# Altium schematic sheet pca20054_sheet5_connectors.SchDoc
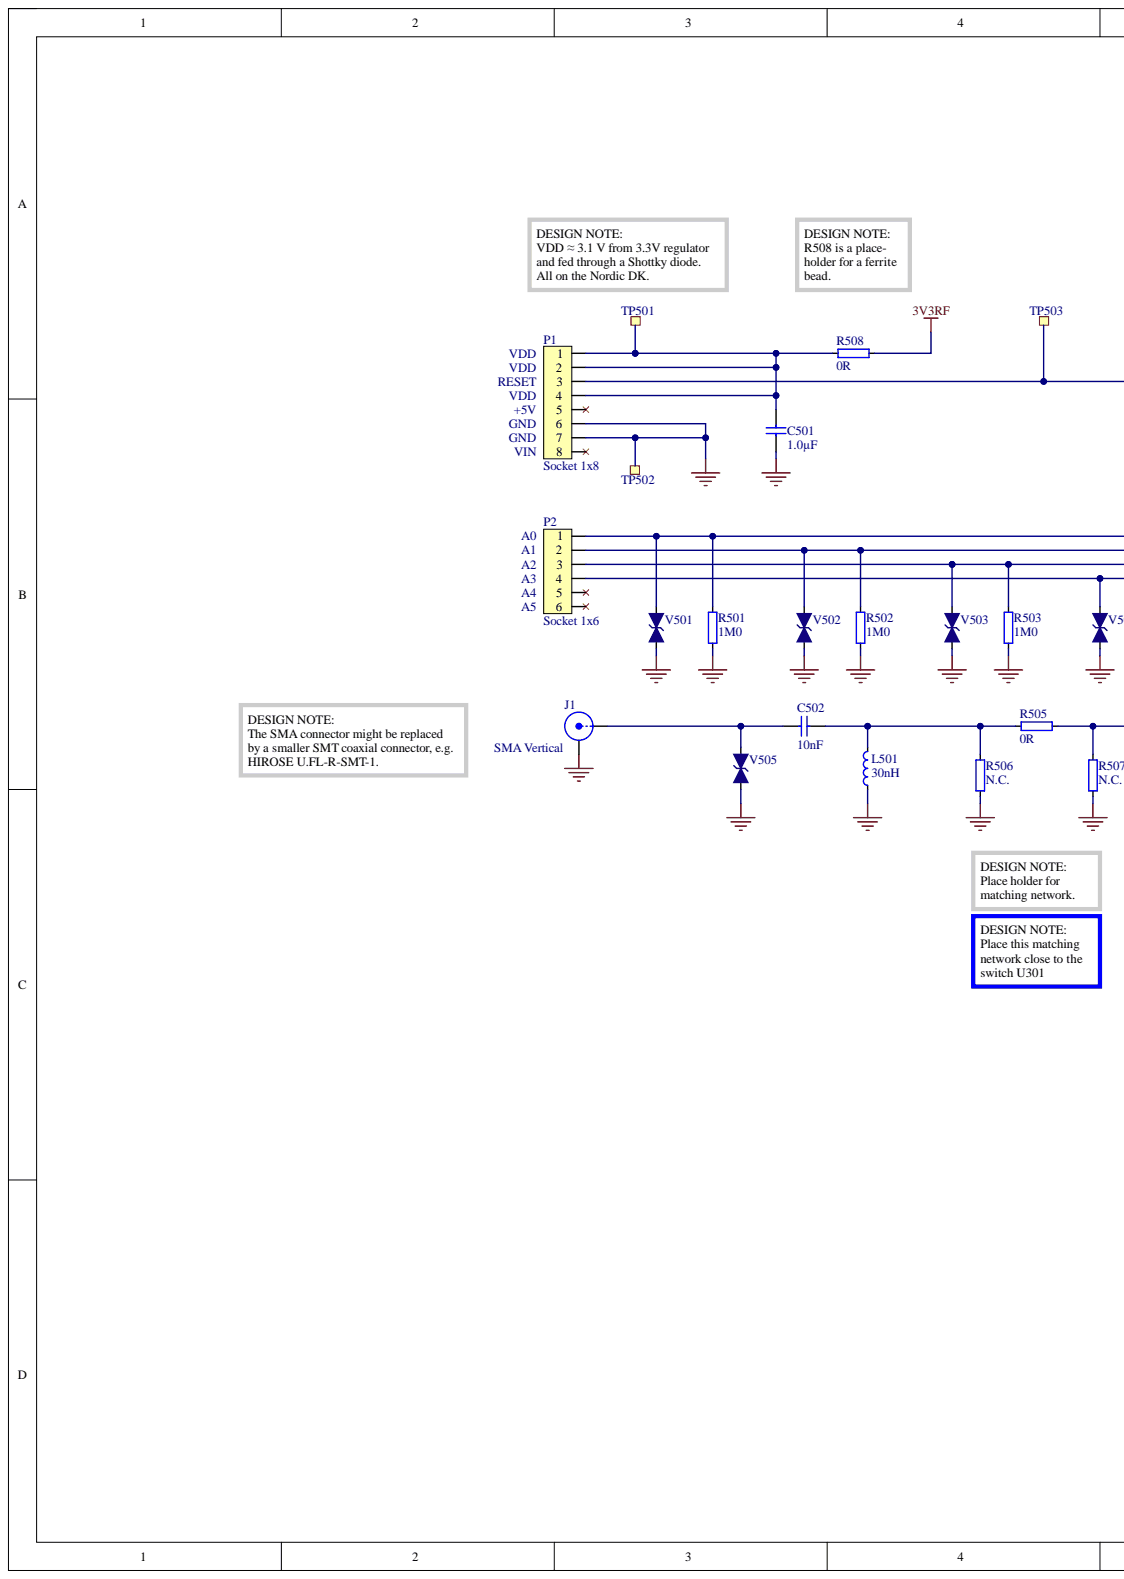
[diagram p0: pca20054_sheet5_connectors.SchDoc, left]
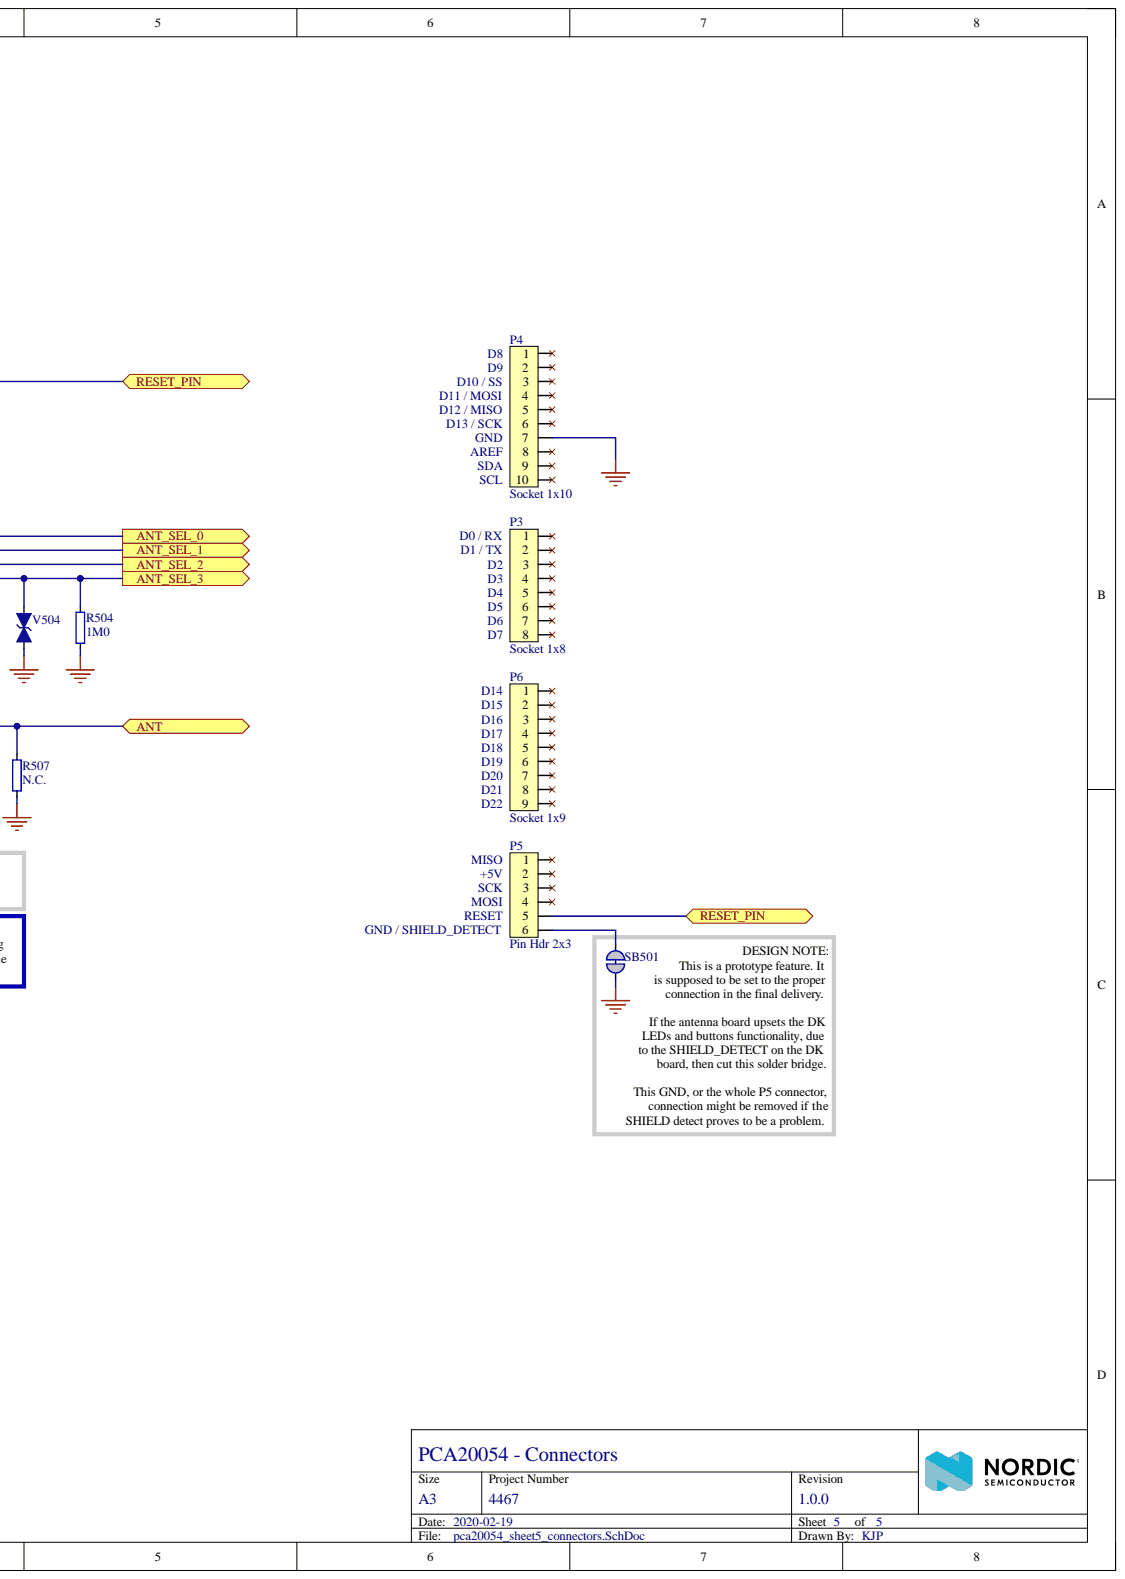
[diagram p1: pca20054_sheet5_connectors.SchDoc, right]

## Parts
C501  1.0µF  [CAPC1608X06L]
C502  10nF  [CAPC1005X04L]
J1  SMA Vertical  [CON_SMA_COAX_FEM_STRAIGHT_5P_1]
L501  30nH  [INDC1005X04L_WW]
P1  Socket 1x8  [SOCKET_1x8_SMD]
P2  Socket 1x6  [SOCKET_1x6_SMD]
P3  Socket 1x8  [SOCKET_1x8_SMD]
P4  Socket 1x10  [SOCKET_1x10_SMD]
P5  Pin Hdr 2x3  [HDR_2x3-SMD]
P6  Socket 1x9  [SOCKET_1x9_SMD]
R501  1M0  [RESC1005X04L]
R502  1M0  [RESC1005X04L]
R503  1M0  [RESC1005X04L]
R504  1M0  [RESC1005X04L]
R505  0R  [RESC1005X04L]
R506  N.C.  [RESC1005X04L_NC]
R507  N.C.  [RESC1005X04L_NC]
R508  0R  [RESC1005X04L]
SB501  Solderbridge  [Solderbridge_Shorted_Power]  (value not drawn)
TP501  Ø1.0mm,SMD  [TP_SMD_Ø1.0mm]  (value not drawn)  (no BOM)
TP502  Ø1.0mm,SMD  [TP_SMD_Ø1.0mm]  (value not drawn)  (no BOM)
TP503  Ø1.0mm,SMD  [TP_SMD_Ø1.0mm]  (value not drawn)  (no BOM)
V501  LXES15AAA1-133  [RESC1005X04L]  (value not drawn)
V502  LXES15AAA1-133  [RESC1005X04L]  (value not drawn)
V503  LXES15AAA1-133  [RESC1005X04L]  (value not drawn)
V504  LXES15AAA1-133  [RESC1005X04L]  (value not drawn)
V505  LXES15AAA1-133  [RESC1005X04L]  (value not drawn)

## Ports
ANT, ANT_SEL_0, ANT_SEL_1, ANT_SEL_2, ANT_SEL_3, RESET_PIN

## Nets
3V3RF: R508.2
GND: C501.1 J1.2 L501.1 P1.6 P1.7 P4.7 R501.1 R502.1 R503.1 R504.1 R506.1 R507.1 SB501.2 TP502.1 V501.2 V502.2 V503.2 V504.2 V505.2
ANT: R505.2 R507.2 ; port ANT
ANT_SEL_0: P2.1 R501.2 V501.1 ; port ANT_SEL_0
ANT_SEL_1: P2.2 R502.2 V502.1 ; port ANT_SEL_1
ANT_SEL_2: P2.3 R503.2 V503.1 ; port ANT_SEL_2
ANT_SEL_3: P2.4 R504.2 V504.1 ; port ANT_SEL_3
RESET_PIN: P1.3 P5.5 TP503.1 ; port RESET_PIN
NetC501_2: C501.2 P1.1 P1.2 P1.4 R508.1 TP501.1
NetC502_1: C502.1 L501.2 R505.1 R506.2
NetC502_2: C502.2 J1.1 V505.1
NetP5_6: P5.6 SB501.1

Not connected: P1 5 8; P2 5 6; P3 1 2 3 4 5 6 7 8; P4 1 2 3 4 5 6 8 9 10; P5 1 2 3 4; P6 1 2 3 4 5 6 7 8 9

## Library data of the parts
C501 = C0603-1µ0: Capacitor, X7R, ±10%; Part number IPS: 00000000616; Artikkelnr Noca: 20039282; Database Table Name: Capacitors$; Note: 16V; Component Kind: Standard; ComponentLink1Description: Datasheet; ComponentLink2Description: Supplier link; Footprint Doc: 0603; LatestRevisionDate: 2013-06-05; Library Name: Nordic Passive DB.DbLib; Part Number: C0603-1µ0; Pin Count: 2; Published: 2009-05-08; Value: 1.0µF; Publisher: Nordic Semiconductor
C502 = C0402-10n: Capacitor, X7R, ±10%; Part number IPS: 00000007784; Artikkelnr Noca: 20039166; Database Table Name: Capacitors$; Note: 16V; Component Kind: Standard; ComponentLink1Description: Datasheet; ComponentLink2Description: Supplier link; Footprint Doc: 0402; LatestRevisionDate: 2009-11-23; Library Name: Nordic Passive DB.DbLib; Part Number: C0402-10n; Pin Count: 2; Published: 2009-05-08; Value: 10nF; Publisher: Nordic Semiconductor
J1 = SMA-Vert-Std_Sigma: SMA Vertical connector; Artikkelnr Noca: N.A.; Database Table Name: Connectors$; Component Kind: Standard; ComponentLink1Description: Datasheet; ComponentLink2Description: Supplier link; Footprint Doc: SMA-VERT; LatestRevisionDate: 2009-12-12; Library Name: Nordic Passive DB.DbLib; Note: SMA vertical; Part Number: SMA-Vert-Std_Sigma; Part number IPS: N.A.; Pin Count: 5; Published: 2009-12-12; Value: SMA Vertical; Publisher: Nordic Semiconductor
L501 = L0402-30n-Wirewound-Murata: Inductor, 270mA, ±2%, 580mΩ, Q=25; Manufacturer: Murata; Manufacturer Part Number: LQW15AN30NG00; Artikkelnr Noca: N.A.; Database Table Name: Inductors$; Note: -55°C ~ 125°C; Component Kind: Standard; ComponentLink1Description: Datasheet; ComponentLink1URL: https://search.murata.co.jp/Ceramy/image/img/P02/JELF243A-0050.pdf; ComponentLink2Description: Supplier link; Footprint Doc: 0402; HelpURL: https://psearch.en.murata.com/inductor/product/LQW15AN30NG00%23.html; LatestRevisionDate: 2019-04-16; Library Name: Nordic Passive DB.DbLib; Part Number: L0402-30n-Wirewound-Murata; Part number IPS: N.A.; Pin Count: 2; Published: 2019-04-16; Supplier 1: Digi-Key; Supplier Part Number 1: 490-6802-1-ND; Value: 30nH; Publisher: Nordic Semiconductor
P1 P3 = Socket 1x8 SMD: Socket 1x8, 2.54mm (100mil), SMD; Manufacturer: W-CON; Manufacturer Part Number: 2171-108MS0CUNR1; Part number IPS: 00000009963; Artikkelnr Noca: 55030002; Database Table Name: Connectors$; Component Kind: Standard; ComponentLink1Description: Datasheet; ComponentLink2Description: Supplier link; Footprint Doc: SOCKET_1x8_SMD; LatestRevisionDate: 2017-10-23; Library Name: Nordic Passive DB.DbLib; Part Number: Socket 1x8 SMD; Pin Count: 8; Published: 2016-01-22; Supplier 1: Cabcon; Supplier 2: Samtec; Supplier Part Number 1: 2171-108MS0CUNR1; Supplier Part Number 2: SSM-108-F-SV-P-TR; Value: Socket 1x8; Publisher: Nordic Semiconductor
P2 = Socket 1x6 SMD: Socket 1x6, 2.54mm (100mil), SMD; Manufacturer: W-CON; Manufacturer Part Number: 2171-106MS0CUNR1; Part number IPS: 00000009964; Artikkelnr Noca: 55030001; Database Table Name: Connectors$; Component Kind: Standard; ComponentLink1Description: Datasheet; ComponentLink2Description: Supplier link; Footprint Doc: SOCKET_1x6_SMD; LatestRevisionDate: 2017-10-23; Library Name: Nordic Passive DB.DbLib; Part Number: Socket 1x6 SMD; Pin Count: 6; Published: 2016-01-22; Supplier 1: Cabcon; Supplier 2: Samtec; Supplier Part Number 1: 2171-106MS0CUNR1; Supplier Part Number 2: SSM-106-F-SV-P-TR; Value: Socket 1x6; Publisher: Nordic Semiconductor
P4 = Socket 1x10 SMD: Socket 1x10, 2.54mm (100mil), SMD; Manufacturer: W-CON; Manufacturer Part Number: 2171-110MS0CUNR1; Part number IPS: 00000009965; Artikkelnr Noca: 55030004; Database Table Name: Connectors$; Component Kind: Standard; ComponentLink1Description: Datasheet; ComponentLink2Description: Supplier link; Footprint Doc: SOCKET_1x10_SMD; LatestRevisionDate: 2016-01-22; Library Name: Nordic Passive DB.DbLib; Part Number: Socket 1x10 SMD; Pin Count: 10; Published: 2016-01-22; Supplier 1: Cabcon; Supplier 2: Samtec; Supplier Part Number 1: 2171-110MS0CUNR1; Supplier Part Number 2: SSM-110-F-SV-P-TR; Value: Socket 1x10; Publisher: Nordic Semiconductor
P5 = Pin Header 2x3 SMD: Pin Header 2x3, 2.54mm (100mil) SMD; Manufacturer: W-CON; Manufacturer Part Number: 1125-1203S0M116R1; Part number IPS: 00000009966; Artikkelnr Noca: 55010007; Database Table Name: Connectors$; Component Kind: Standard; ComponentLink1Description: Datasheet; ComponentLink2Description: Supplier link; Footprint Doc: HDR_2x3-SMD; HelpURL: \\hizz\pro\app9717\Datasheet\connectors\W-CON\1125-12XXXXMXXXXX.PDF; LatestRevisionDate: 2016-01-22; Library Name: Nordic Passive DB.DbLib; Note: SMD; Part Number: Pin Header 2x3 SMD; Pin Count: 6; Published: 2016-01-22; Supplier 1: Cabcon; Supplier 2: Samtec; Supplier Part Number 1: 1125-1203S0M116R1; Supplier Part Number 2: TSM-103-01-L-DV; Value: Pin Hdr 2x3; Publisher: Nordic Semiconductor
P6 = Socket 1x9 SMD: Socket 1x9, 2.54mm (100mil), SMD; Manufacturer: W-CON; Manufacturer Part Number: 2171-109MS0CUNR1; Part number IPS: N.A.; Artikkelnr Noca: 55030003; Database Table Name: Connectors$; Component Kind: Standard; ComponentLink1Description: Datasheet; ComponentLink2Description: Supplier link; Footprint Doc: SOCKET_1x9_SMD; LatestRevisionDate: 2017-10-23; Library Name: Nordic Passive DB.DbLib; Part Number: Socket 1x9 SMD; Pin Count: 9; Published: 2016-01-22; Supplier 1: Cabcon; Supplier 2: Samtec; Supplier Part Number 1: 2171-109MS0CUNR1; Supplier Part Number 2: SSM-109-L-SV-P-TR; Value: Socket 1x9; Publisher: Nordic Semiconductor
R501-R504 = R0402-1M0: Resistor, ±1%, 0.063W; Part number IPS: 00000006807; Artikkelnr Noca: 27049145; Database Table Name: Resistors$; Note: Chip Res 1%; Component Kind: Standard; ComponentLink1Description: Datasheet; ComponentLink2Description: Supplier link; Footprint Doc: 0402; LatestRevisionDate: 2009-11-23; Library Name: Nordic Passive DB.DbLib; Part Number: R0402-1M0; Pin Count: 2; Published: 2009-05-08; Value: 1M0; Publisher: Nordic Semiconductor
R505 R508 = R0402-0R: Resistor, ±5%, 0.063W; Part number IPS: 00000007128; Artikkelnr Noca: 27049000; Database Table Name: Resistors$; Note: Chip Res 5%; Component Kind: Standard; ComponentLink1Description: Datasheet; ComponentLink2Description: Supplier link; Footprint Doc: 0402; LatestRevisionDate: 2009-11-23; Library Name: Nordic Passive DB.DbLib; Part Number: R0402-0R; Pin Count: 2; Published: 2009-05-08; Supplier 1: Farnell; Supplier Part Number 1: 146-9661; Value: 0R; Publisher: Nordic Semiconductor
R506 R507 = R0402-N.C.: Not mounted; Artikkelnr Noca: N.A.; Assembly: Not mounted; Database Table Name: Resistors$; Note: Chip Res; Component Kind: Standard; ComponentLink1Description: Datasheet; ComponentLink2Description: Supplier link; Footprint Doc: 0402; LatestRevisionDate: 2009-05-08; Library Name: Nordic Passive DB.DbLib; Part Number: R0402-N.C.; Part number IPS: N.A.; Pin Count: 2; Published: 2009-05-08; Value: N.C.; Publisher: Nordic Semiconductor
SB501 = Solderbridge Shorted Power: Shorted Solderbridge Power; Artikkelnr Noca: N.A.; Database Table Name: Connectors$; Component Kind: Net Tie; ComponentLink1Description: Datasheet; ComponentLink2Description: Supplier link; Footprint Doc: Solderbridge_Shorted_Power; LatestRevisionDate: 2012-08-07; Library Name: Nordic Passive DB.DbLib; Part Number: Solderbridge Shorted Power; Part number IPS: N.A.; Pin Count: 2; Published: 2012-08-07; Value: Solderbridge; Publisher: Nordic Semiconductor
TP501-TP503 = TP_SMD_Ø1.0MM: 1.0mm circular SMD testpad; Manufacturer: Nordic Semiconductor; Artikkelnr Noca: N.A.; Database Table Name: Connectors$; ComponentLink1Description: Datasheet; ComponentLink2Description: Supplier link; Footprint Doc: TP_SMD_Ø1.0mm; LatestRevisionDate: 2013-06-11; Library Name: Nordic Passive DB.DbLib; Part Number: TP_SMD_Ø1.0MM; Part number IPS: N.A.; Pin Count: 1; Published: 2013-06-11; Value: Ø1.0mm,SMD; Publisher: Nordic Semiconductor
V501-V505 = LXES15AAA1-133: TVS DIODE 15VWM 0402; Manufacturer: Murata; Artikkelnr Noca: N.A.; Database Table Name: Semiconductors$; Component Kind: Standard; ComponentLink1Description: Datasheet; ComponentLink2Description: Supplier link; Footprint Doc: 0402; HelpURL: http://www.murata.com/en-us/products/productdata/8796832759838/CRESD-E.pdf; LatestRevisionDate: 2017-09-20; Library Name: Nordic Discrete DB.DbLib; Manufacturer Part Number: LXES15AAA1-133; Part Number: LXES15AAA1-133; Part number IPS: N.A.; Pin Count: 2; Published: 2017-09-20; Supplier 1: Digi-Key; Supplier 2: Mouser; Supplier Part Number 1: 490-13209-6-ND; Supplier Part Number 2: 81-LXES15AAA1-133; Value: LXES15AAA1-133; Publisher: Nordic Semiconductor
